# Altium schematic sheet SolarPanels.SchDoc
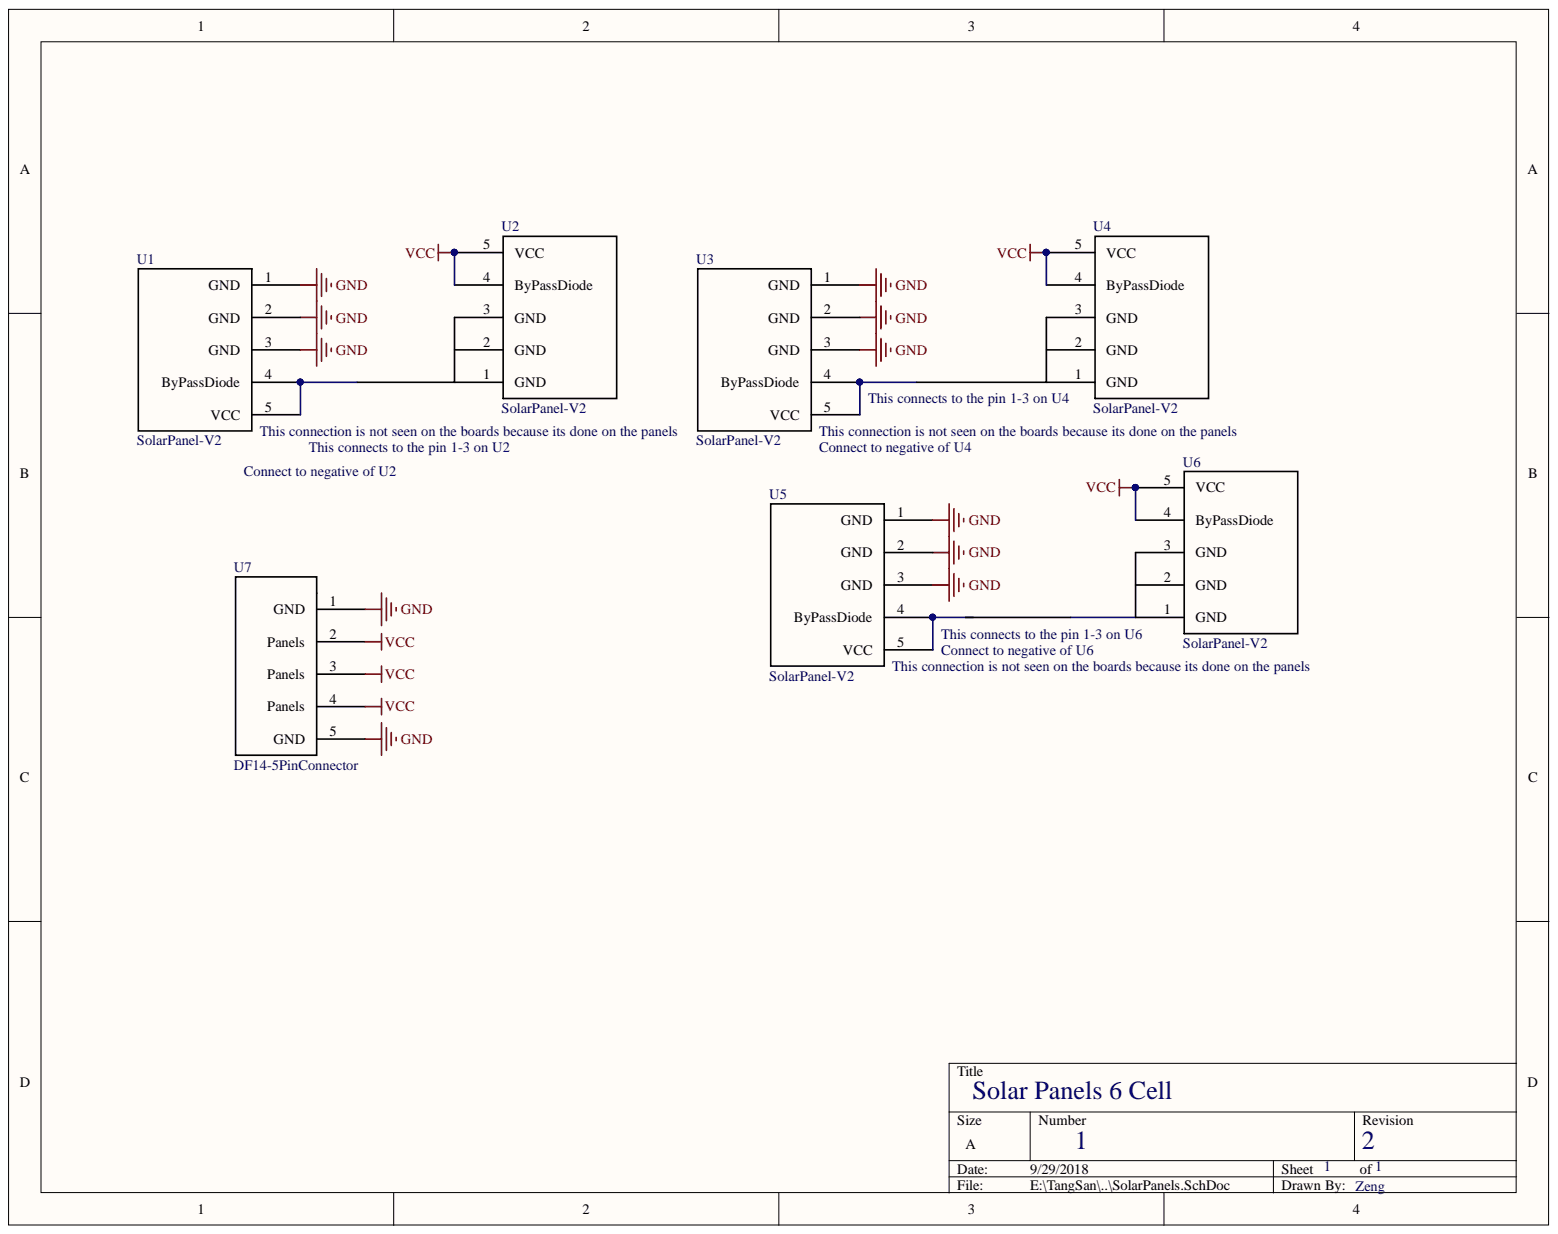
[diagram p0: SolarPanels.SchDoc]

## Parts
U1  SolarPanel-V2  [SolarPanel_V2_1]
U2  SolarPanel-V2  [SOLARPANEL_V2_2]
U3  SolarPanel-V2  [SolarPanel_V2_1]
U4  SolarPanel-V2  [SOLARPANEL_V2_2]
U5  SolarPanel-V2  [SolarPanel_V2_1]
U6  SolarPanel-V2  [SOLARPANEL_V2_2]
U7  DF14-5PinConnector  [DF14-5P-1.25H(55)]

## Nets
GND: U1.1(GND) U1.2(GND) U1.3(GND) U3.1(GND) U3.2(GND) U3.3(GND) U5.1(GND) U5.2(GND) U5.3(GND) U7.1(GND) U7.5(GND)
VCC: U2.4(ByPassDiode) U2.5(VCC) U4.4(ByPassDiode) U4.5(VCC) U6.4(ByPassDiode) U6.5(VCC) U7.2(Panels) U7.3(Panels) U7.4(Panels)
NetU1_4: U1.4(ByPassDiode) U1.5(VCC)
NetU3_4: U3.4(ByPassDiode) U3.5(VCC)
NetU5_4: U5.4(ByPassDiode) U5.5(VCC)

Not connected: U2 1(GND) 2(GND) 3(GND); U4 1(GND) 2(GND) 3(GND); U6 1(GND) 2(GND) 3(GND)

## Library data of the parts
U1-U6 = SolarPanel-V2: Component Kind: Standard; Library Name: SolarPanelsSchematicLibrary.SCHLIB
U7 = DF14-5PinConnector: Component Kind: Standard; Library Name: SolarPanelsSchematicLibrary.SCHLIB
